# Altium schematic sheet 07_sensors.SchDoc(07_sensors)
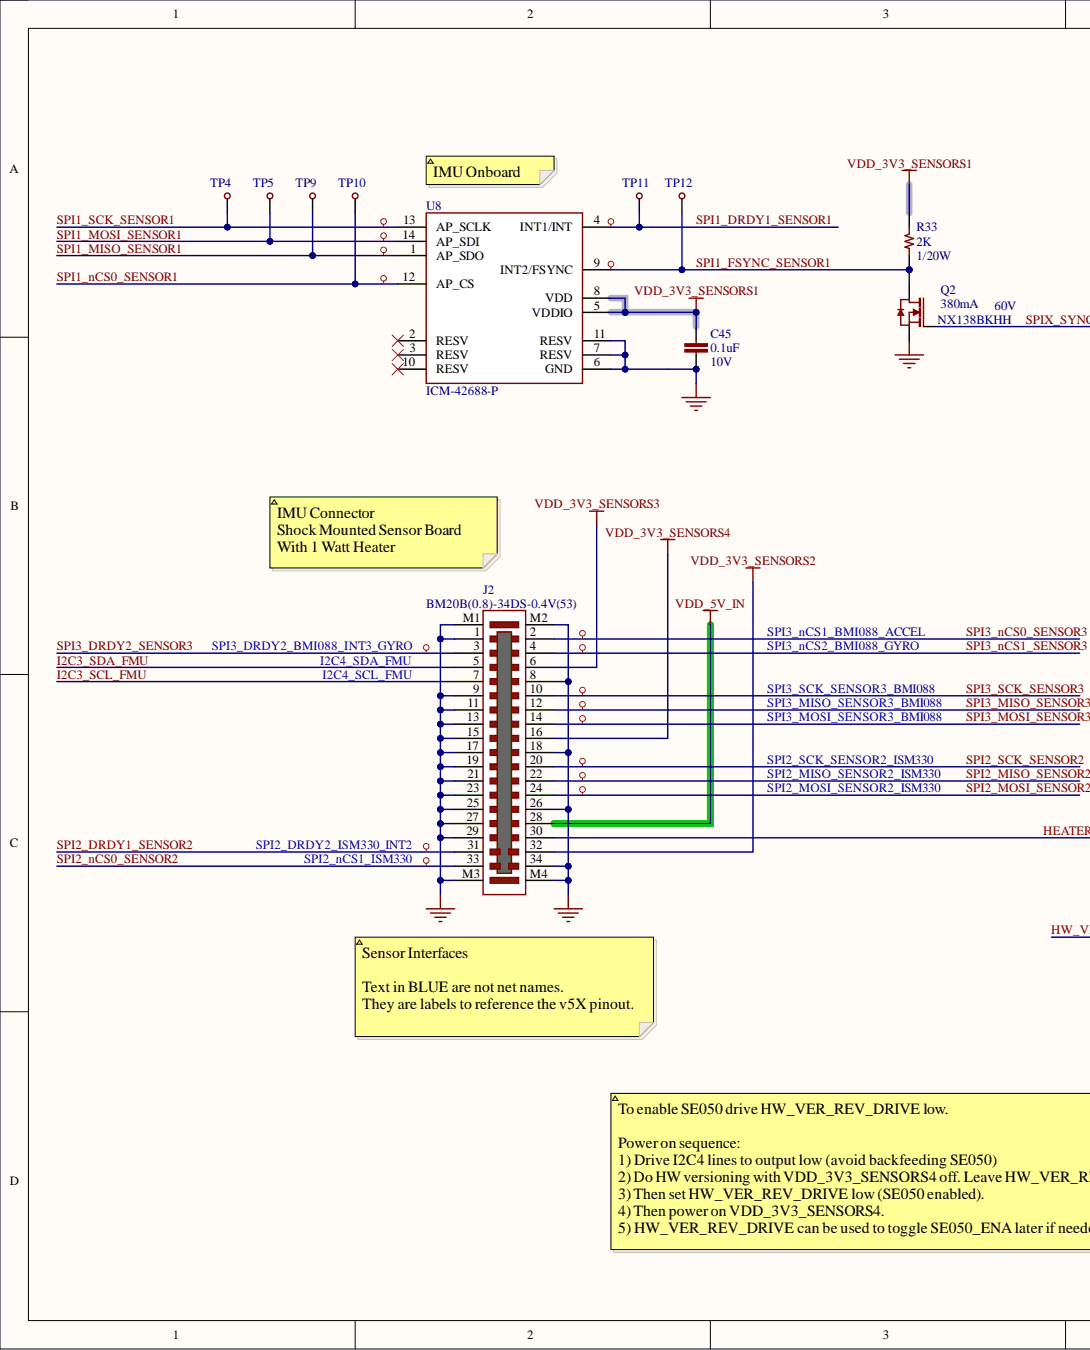
[diagram p0: 07_sensors.SchDoc, left]
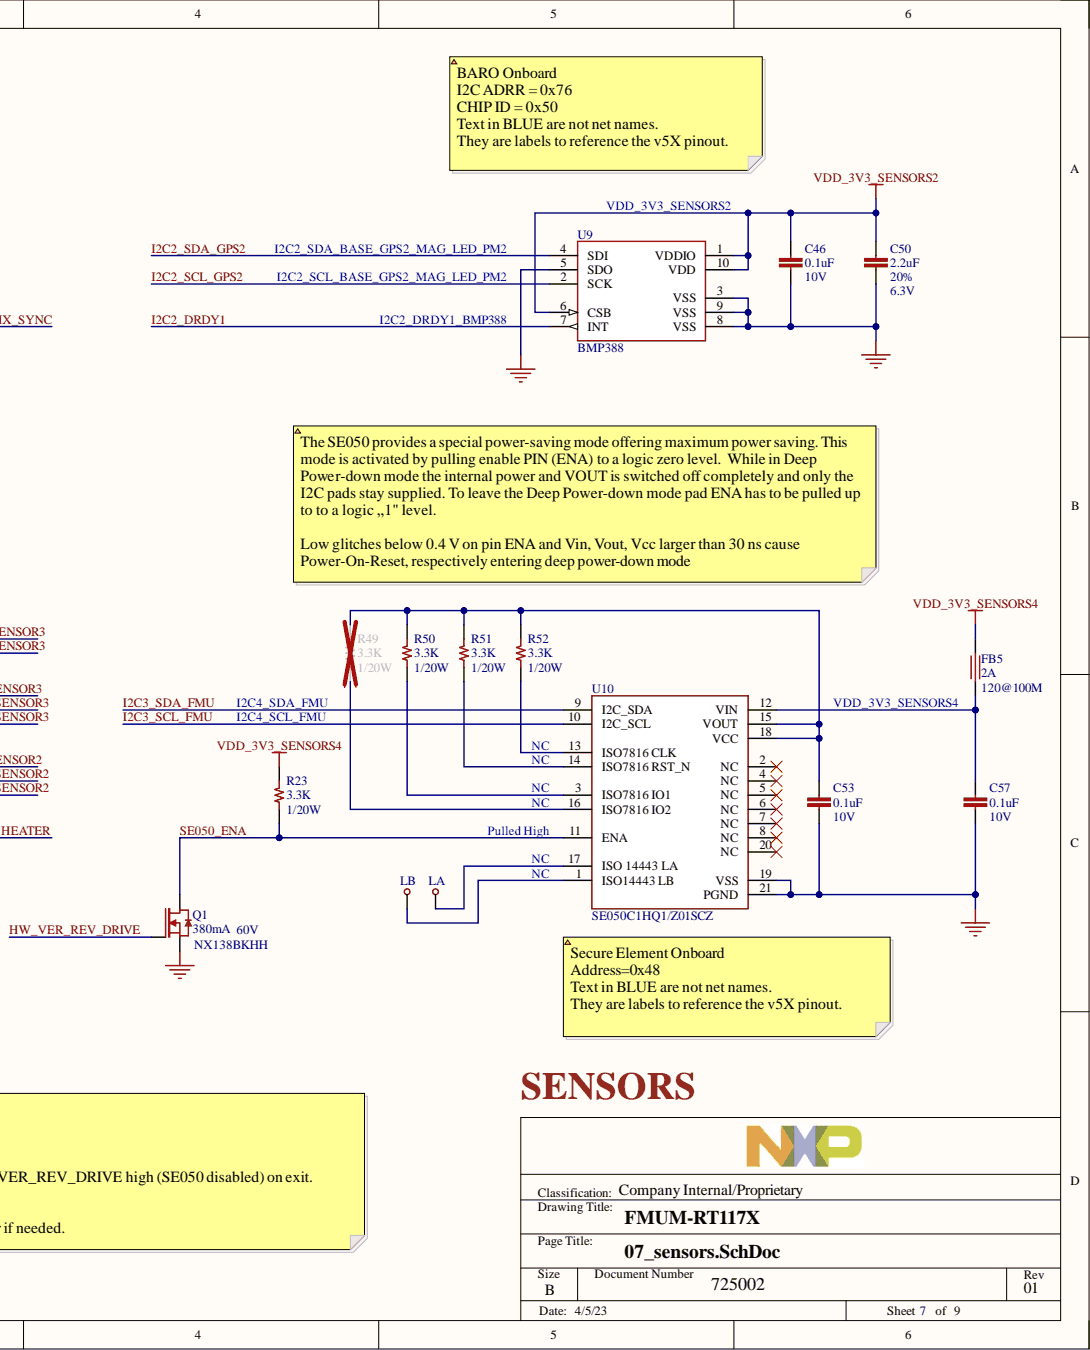
[diagram p1: 07_sensors.SchDoc, right]

## Parts
C45  value 0.1uF  Voltage - Rated: 10V  [CAPC60X30X33L15N]  (hidden comment: CAP CER 0.1uF 20% 10V X5R 0201)
C46  value 0.1uF  Voltage - Rated: 10V  [CAPC60X30X33L15N]  (hidden comment: CAP CER 0.1uF 20% 10V X5R 0201)
C50  value 2.2uF  Tolerance: 20%  Voltage - Rated: 6.3V  [CAPC60X30X33L15N]  (hidden comment: CAP CER 2.2uF 20% 10V X5R 0201)
C53  value 0.1uF  Voltage - Rated: 10V  [CAPC60X30X33L15N]  (hidden comment: CAP CER 0.1uF 20% 10V X5R 0201)
C57  value 0.1uF  Voltage - Rated: 10V  [CAPC60X30X33L15N]  (hidden comment: CAP CER 0.1uF 20% 10V X5R 0201)
FB5  value 120@100M  Current: 2A  [BEADC100X50X55L25]  (hidden comment: IND FERRITE 120@100M 2A 0402)
J2  Manufacturer Part Number: BM20B(0.8)-34DS-0.4V(53)  [HIROSE_BM20B-0.8-34DS-0_4_53]  (hidden comment: CONN RCPT 34POS 0.4MM BM20 SMD GOLD)
LA  TESTPOINT PAD NANO SMD  [TEST_PAD_0.3MM]  (value not drawn)  (no BOM)
LB  TESTPOINT PAD NANO SMD  [TEST_PAD_0.3MM]  (value not drawn)  (no BOM)
Q1  Current: 380mA  Manufacturer Part Number: NX138BKHH  [TRXDFN3_62X62X40L15X15N]  (hidden comment: TRANSISTOR N-CH 60V 380MA DFN0606-3)
Q2  Current: 380mA  Manufacturer Part Number: NX138BKHH  [TRXDFN3_62X62X40L15X15N]  (hidden comment: TRANSISTOR N-CH 60V 380MA DFN0606-3)
R23  value 3.3K  Power: 1/20W  [RESC60X30X33L15N]  (hidden comment: RES SMD 3.3K OHM 1% 1/20W 0201)
R33  value 2K  Power: 1/20W  [RESC60X30X33L15N]  (hidden comment: RES SMD 2K OHM 1% 1/20W 0201)
R49  value 3.3K  Power: 1/20W  [RESC60X30X33L15N]  (hidden comment: RES SMD 3.3K OHM 1% 1/20W 0201)
R50  value 3.3K  Power: 1/20W  [RESC60X30X33L15N]  (hidden comment: RES SMD 3.3K OHM 1% 1/20W 0201)
R51  value 3.3K  Power: 1/20W  [RESC60X30X33L15N]  (hidden comment: RES SMD 3.3K OHM 1% 1/20W 0201)
R52  value 3.3K  Power: 1/20W  [RESC60X30X33L15N]  (hidden comment: RES SMD 3.3K OHM 1% 1/20W 0201)
TP4  TESTPOINT PAD NANO SMD  [TEST_PAD_0.3MM]  (value not drawn)  (no BOM)
TP5  TESTPOINT PAD NANO SMD  [TEST_PAD_0.3MM]  (value not drawn)  (no BOM)
TP9  TESTPOINT PAD NANO SMD  [TEST_PAD_0.3MM]  (value not drawn)  (no BOM)
TP10  TESTPOINT PAD NANO SMD  [TEST_PAD_0.3MM]  (value not drawn)  (no BOM)
TP11  TESTPOINT PAD NANO SMD  [TEST_PAD_0.3MM]  (value not drawn)  (no BOM)
TP12  TESTPOINT PAD NANO SMD  [TEST_PAD_0.3MM]  (value not drawn)  (no BOM)
U8  Manufacturer Part Number: ICM-42688-P  [PQFN14P50_250X300X86L45X25N]  (hidden comment: IC IMU 6-AXIS ACCEL/GYRO I2C/SPI 14LGA)
U9  Manufacturer Part Number: BMP388  [BOSCH_BMP388]  (hidden comment: IC SENSOR PRESSURE ABS 10WFLGA)
U10  Manufacturer Part Number: SE050C1HQ1/Z01SCZ  [QFN21P40_300X300X33L30X20T190]  (hidden comment: IC IoT Secure Element 20-HX2QFN)

## Nets
GND: C45.2 C46.2 C50.2 C53.2 C57.2 J2.1 J2.8 J2.9 J2.11 J2.13 J2.15 J2.17 J2.18 J2.19 J2.21 J2.23 J2.25 J2.26 J2.27 J2.29 J2.34 J2.M1 J2.M2 J2.M3 J2.M4 Q1.2 Q2.2 U8.6(GND) U8.7(RESV) U8.11(RESV) U9.3(VSS) U9.5(SDO) U9.8(VSS) U9.9(VSS) U10.19(VSS) U10.21(PGND)
VDD_3V3_SENSORS1: C45.1 R33.1 U8.5(VDDIO) U8.8(VDD)
VDD_3V3_SENSORS2: C46.1 C50.1 J2.32 U9.1(VDDIO) U9.6(CSB) U9.10(VDD)
VDD_3V3_SENSORS3: J2.6
VDD_3V3_SENSORS4: FB5.1 J2.16 R23.1
VDD_5V_IN: J2.28
HEATER: J2.30
HW_VER_REV_DRIVE: Q1.1
I2C2_DRDY1: U9.7(INT)
I2C2_SCL_GPS2: U9.2(SCK)
I2C2_SDA_GPS2: U9.4(SDI)
I2C3_SCL_FMU: J2.7 U10.10(I2C_SCL)
I2C3_SDA_FMU: J2.5 U10.9(I2C_SDA)
SE050_ENA: Q1.3 R23.2 U10.11(ENA)
SPI1_DRDY1_SENSOR1: TP11.1 U8.4(INT1/INT)
SPI1_FSYNC_SENSOR1: Q2.3 R33.2 TP12.1 U8.9(INT2/FSYNC)
SPI1_MISO_SENSOR1: TP9.1 U8.1(AP_SDO)
SPI1_MOSI_SENSOR1: TP5.1 U8.14(AP_SDI)
SPI1_nCS0_SENSOR1: TP10.1 U8.12(AP_CS)
SPI1_SCK_SENSOR1: TP4.1 U8.13(AP_SCLK)
SPI2_DRDY1_SENSOR2: J2.31
SPI2_MISO_SENSOR2: J2.22
SPI2_MOSI_SENSOR2: J2.24
SPI2_nCS0_SENSOR2: J2.33
SPI2_SCK_SENSOR2: J2.20
SPI3_DRDY2_SENSOR3: J2.3
SPI3_MISO_SENSOR3: J2.12
SPI3_MOSI_SENSOR3: J2.14
SPI3_nCS0_SENSOR3: J2.2
SPI3_nCS1_SENSOR3: J2.4
SPI3_SCK_SENSOR3: J2.10
SPIX_SYNC: Q2.1
NetC53_1: C53.1 R49.1 R50.1 R51.1 R52.1 U10.15(VOUT) U10.18(VCC)
NetC57_1: C57.1 FB5.2 U10.12(VIN)
NetLA_1: LA.1 U10.17(ISO_14443_LA)
NetLB_1: LB.1 U10.1(ISO14443_LB)
NetR49_2: R49.2 U10.16(ISO7816_IO2)
NetR50_2: R50.2 U10.3(ISO7816_IO1)
NetR51_2: R51.2 U10.14
NetR52_2: R52.2 U10.13(ISO7816_CLK)

Not connected: U8 2(RESV) 3(RESV) 10(RESV); U10 2(NC) 4(NC) 5(NC) 6(NC) 7(NC) 8(NC) 20(NC)

## Library data of the parts
C45 C46 C53 C57 = CAP_0.1uF_10V_X5R_0201: Manufacturer: Murata Electronics; Manufacturer Part Number: GRM033R61A104ME15D; Category: Capacitors; Component Kind: Standard; ComponentLink1Description: https://www.digikey.com/en/products/detail/murata-electronics/GRM033R61A104ME15D/2175191; ComponentLink1URL: https://www.digikey.com/en/products/detail/murata-electronics/GRM033R61A104ME15D/2175191; Description: CAP CER 0.1uF 20% 10V X5R 0201; Operating Temperature: -55°C ~ 85°C; Packaging: Cut Tape (CT); Part Status: Active; Pricing 1: 1=0.10 (USD); Stock 1: 12909013; Supplier 1: Digi-Key; Supplier Part Number 1: 490-5405-1-ND; Tolerance: 20%; Library Name: NscDG_Integrated_Library.SchLib
C50 = CAP_2.2uF_6.3V_X5R_0201: Manufacturer: Murata Electronics; Manufacturer Part Number: GRM033R60J225ME47D; Category: Capacitors; Component Kind: Standard; ComponentLink1Description: https://www.digikey.com/en/products/detail/murata-electronics/GRM033R60J225ME47D/5877388; ComponentLink1URL: https://www.digikey.com/en/products/detail/murata-electronics/GRM033R60J225ME47D/5877388; Description: CAP CER 2.2uF 20% 10V X5R 0201; Operating Temperature: -55°C ~ 85°C; Packaging: Cut Tape (CT); Part Status: Active; Pricing 1: 1=0.18 (USD); Stock 1: 9156088; Supplier 1: Digi-Key; Supplier Part Number 1: 490-13225-1-ND; Library Name: NscDG_Integrated_Library.SchLib
FB5 = IND_FERRITE_120@120M_2A_0402: Manufacturer: Murata Electronics North America; Manufacturer Part Number: BLM15PX121SN1D; Category: Inductors; Component Kind: Standard; ComponentLink1Description: https://www.digikey.com/product-detail/en/murata-electronics/BLM15PX121SN1D/490-9656-1-ND/4934752; ComponentLink1URL: https://www.digikey.com/product-detail/en/murata-electronics/BLM15PX121SN1D/490-9656-1-ND/4934752; Description: IND FERRITE 120@100M 2A 0402; Operating Temperature: -55°C ~ 125°C; Packaging: Cut Tape (CT); Part Status: Active; Pricing 1: 1=0.10 (USD); Stock 1: 98122; Supplier 1: Digi-Key; Supplier Part Number 1: 490-9656-1-ND; Library Name: NscDG_Integrated_Library.SchLib
J2 = CONN_RCPT_2X17_RECPT_0.4MM_BM20_SMD: Manufacturer: Hirose Electric Co Ltd; Number of Positions: 34; Pitch: 0.016" (0.40mm); System: Connector; Category: Connectors, Interconnects; Component Kind: Standard; ComponentLink1Description: https://www.digikey.com/product-detail/en/hirose-electric-co-ltd/BM20B-0.8-34DS-0.4V-53/H122171CT-ND/4552339; ComponentLink1URL: https://www.digikey.com/product-detail/en/hirose-electric-co-ltd/BM20B-0.8-34DS-0.4V-53/H122171CT-ND/4552339; Description: CONN RCPT 34POS 0.4MM BM20 SMD GOLD; Mounting Type: Surface Mount; Number of Rows: 2; Operating Temperature: -55°C ~ 85°C; Packaging: Cut Tape (CT); Part Status: Active; Pricing 1: 1=1.43 (USD); Stock 1: 1788; Supplier 1: Digi-Key; Supplier Part Number 1: H122171CT-ND; Library Name: NscDG_Integrated_Library.SchLib
LA LB TP4 TP5 TP9-TP12 = TESTPOINT_PAD_NANO_SMD: CATEGORY: Test and Measurement; Description: TESTPOINT PAD NANO SMD; Manufacturer: N/A; Library Name: NscDG_Integrated_Library.SchLib
Q1 Q2 = TRAN_MOSFET_N-CH_NX138BKHH_DFN0606-3: Manufacturer: Nexperia USA Inc.; Category: Discrete Semiconductor Products; Component Kind: Standard; ComponentLink1Description: https://www.digikey.com/en/products/detail/nexperia-usa-inc/NX138BKHH/13575152; ComponentLink1URL: https://www.digikey.com/en/products/detail/nexperia-usa-inc/NX138BKHH/13575152; Description: TRANSISTOR N-CH 60V 380MA DFN0606-3; Packaging: Cut Tape (CT); Part Status: Active; Pricing 1: 1=0.28 (USD); Stock 1: 9500; Supplier 1: Digi-Key; Supplier Part Number 1: 1727-NX138BKHHCT-ND; Voltage: 60V; Library Name: NscDG_Integrated_Library.SchLib
R23 R49-R52 = RES_3.3K_1%_1/20W_0201: Manufacturer: Yageo; Manufacturer Part Number: RC0201FR-073K3L; Category: Resistors; Component Kind: Standard; ComponentLink1Description: https://www.digikey.com/en/products/detail/yageo/RC0201FR-073K3L/3202407; ComponentLink1URL: https://www.digikey.com/en/products/detail/yageo/RC0201FR-073K3L/3202407; Description: RES SMD 3.3K OHM 1% 1/20W 0201; Operating Temperature: -55°C ~ 125°C; Packaging: Cut Tape (CT); Part Status: Active; Pricing 1: 1=0.1, 10=0.014, 100=0.0056, 1000=0.00253, 2500=0.0022, 5000=0.00181 (USD); Stock 1: 628808; Supplier 1: Digi-Key; Supplier Part Number 1: YAG2285CT-ND; Tolerance: ±1%; Library Name: NscDG_Integrated_Library.SchLib
R33 = RES_2K_1%_1/20W_0201: Manufacturer: Yageo; Manufacturer Part Number: RC0201FR-072KL; Category: Resistors; Component Kind: Standard; ComponentLink1Description: https://www.digikey.com/en/products/detail/yageo/RC0201FR-072KL/3202400; ComponentLink1URL: https://www.digikey.com/en/products/detail/yageo/RC0201FR-072KL/3202400; Description: RES SMD 2K OHM 1% 1/20W 0201; Operating Temperature: -55°C ~ 125°C; Packaging: Cut Tape (CT); Part Status: Active; Pricing 1: 1=0.1, 10=0.014, 100=0.0056, 1000=0.00253, 2500=0.0022, 5000=0.00181 (USD); Stock 1: 1035108; Supplier 1: Digi-Key; Supplier Part Number 1: YAG2280CT-ND; Tolerance: ±1%; Library Name: NscDG_Integrated_Library.SchLib
U8 = IC_ICM_42688_P_14LGA: Manufacturer: TDK InvenSense; Category: Sensors, Transducers; Component Kind: Standard; ComponentLink1Description: https://www.digikey.com/product-detail/en/tdk-invensense/ICM-42688-P/1428-ICM-42688-PCT-ND/11679713; ComponentLink1URL: https://www.digikey.com/product-detail/en/tdk-invensense/ICM-42688-P/1428-ICM-42688-PCT-ND/11679713; Description: IC IMU 6-AXIS ACCEL/GYRO I2C/SPI 14LGA; Operating Temperature: -40°C ~ 85°C (TA); Part Status: Active; Pricing 1: 1=8.3 (USD); Stock 1: 3939; Supplier 1: Digi-Key; Supplier Part Number 1: 1428-ICM-42688-PCT-ND; Library Name: NscDG_Integrated_Library.SchLib
U9 = IC_BMP388_10WFLGA: Manufacturer: Bosch Sensortec; Category: Sensors, Transducers; Component Kind: Standard; ComponentLink1Description: https://www.digikey.com/product-detail/en/bosch-sensortec/BMP388/828-1079-1-ND/8322640; ComponentLink1URL: https://www.digikey.com/product-detail/en/bosch-sensortec/BMP388/828-1079-1-ND/8322640; Description: IC SENSOR PRESSURE ABS 10WFLGA; Operating Temperature: -40°C ~ 85°C (TA); Part Status: Active; Pricing 1: 1=3.93, 5=3.466, 10=3.061, 25=2.4832, 50=2.1946, 100=2.1368, 500=1.79026, 1000=1.67475, 5000=1.55925 (USD); Stock 1: 39511; Supplier 1: Digi-Key; Supplier Part Number 1: 828-1079-1-ND; Library Name: NscDG_Integrated_Library.SchLib
U10 = IC_SE050C1HQ1: Manufacturer: NXP USA Inc.; Category: Integrated Circuits (ICs); Component Kind: Standard; ComponentLink1Description: https://www.digikey.com/products/en/integrated-circuits-ics/specialized-ics/686?k=SE050C1HQ1%2FZ01SCZ&k=&pkeyword=SE050C1HQ1%2FZ01SCZ&sv=0&pv7=2&sf=0&quantity=&ColumnSort=0&page=1&pageSize=25; ComponentLink1URL: https://www.digikey.com/products/en/integrated-circuits-ics/specialized-ics/686?k=SE050C1HQ1%2FZ01SCZ&k=&pkeyword=SE050C1HQ1%2FZ01SCZ&sv=0&pv7=2&sf=0&quantity=&ColumnSort=0&page=1&pageSize=25; Description: IC IoT Secure Element 20-HX2QFN; Max Operating Temperature: 85°C; Min Operating Temperature: -25°C; Packaging: Cut Tape (CT); Pricing 1: 1=4.09 (USD); Stock 1: 1530; Supplier 1: Digi-Key; Supplier Part Number 1: 568-15377-1-ND; Library Name: NscDG_Integrated_Library.SchLib
